# Altium schematic sheet PSU.SchDoc
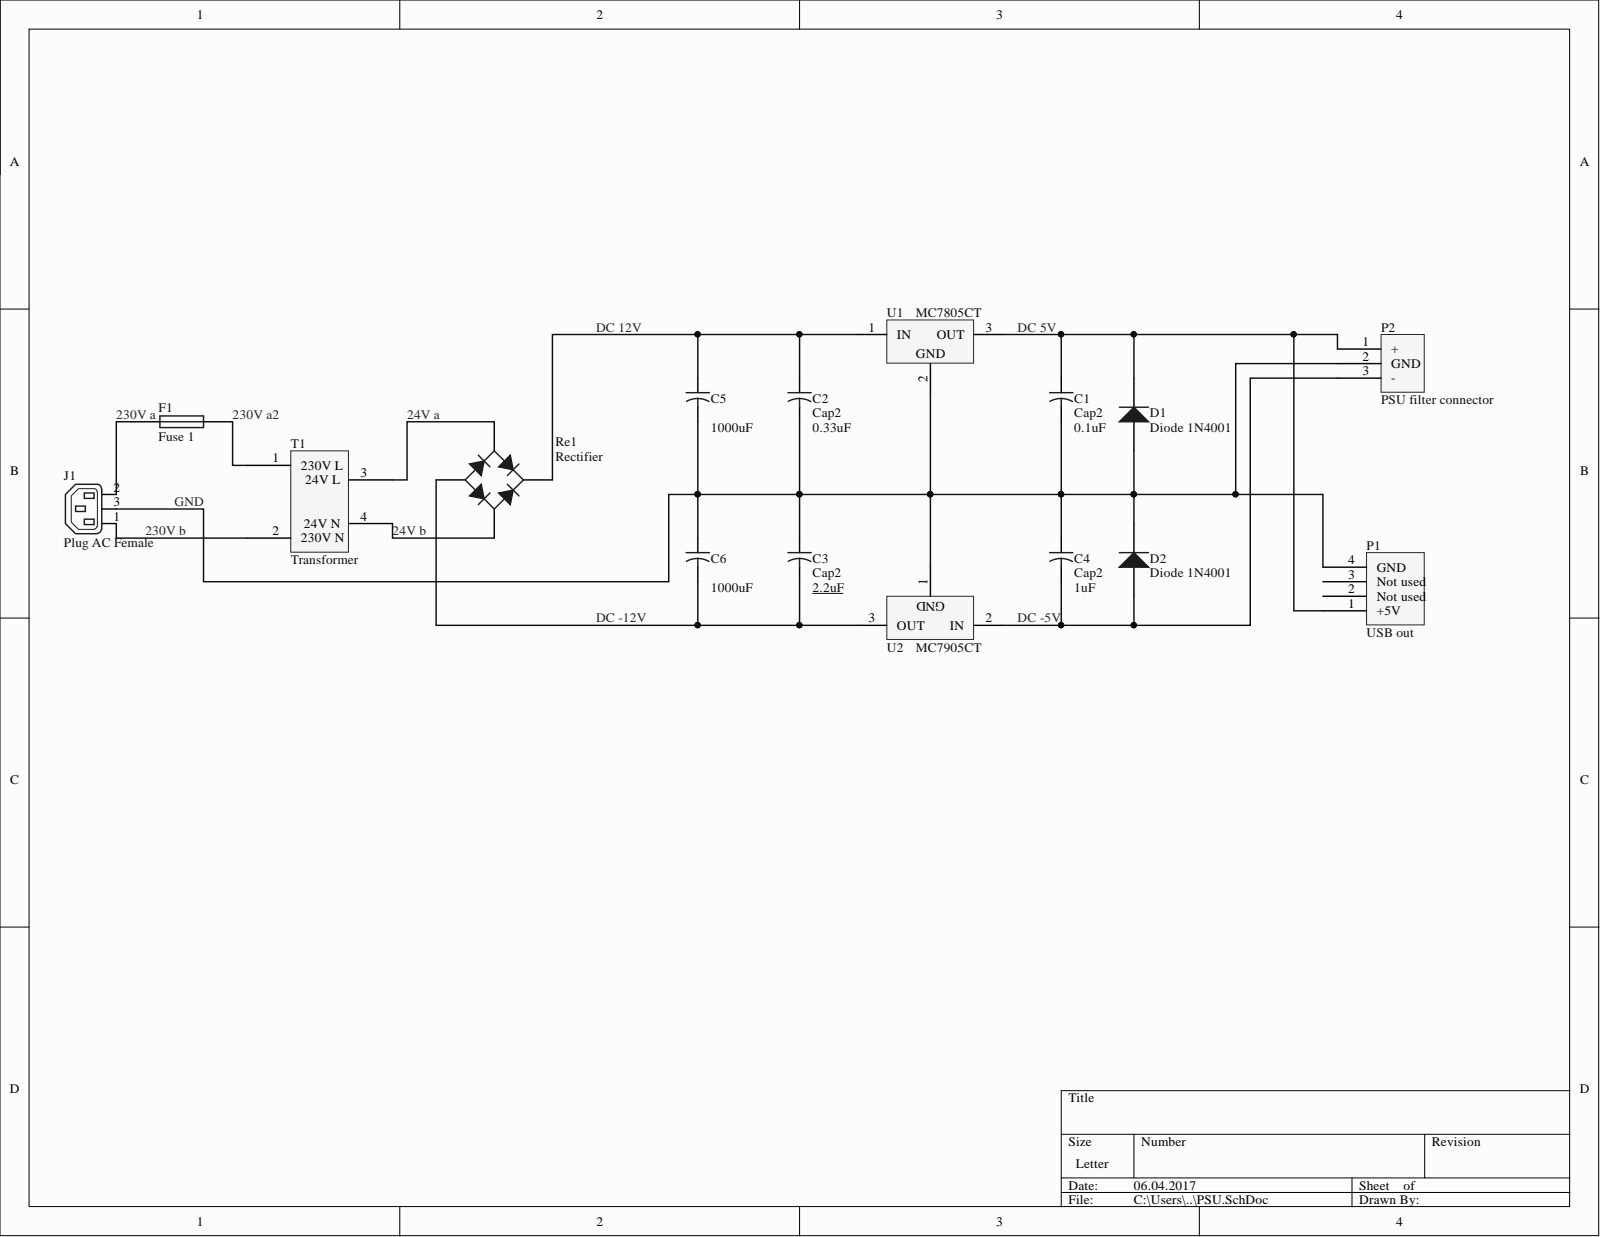
[diagram p0: PSU.SchDoc]

## Parts
C1  Cap2  value 0.1uF  [Cap Jamicon 1uF]
C2  Cap2  value 0.33uF  [Cap Jamicon 330nF]
C3  Cap2  value 2.2uF  [Cap Panasonic 2.2uF]
C4  Cap2  value 1uF  [Cap Jamicon 1uF]
C5  value 1000uF  [Cap 1000uF]
C6  value 1000uF  [Cap 1000uF]
D1  Diode 1N4001  [DO-41]
D2  Diode 1N4001  [DO-41]
F1  Fuse 1  [PIN-W2/E2.8]
J1  Plug AC Female  [Power input PSU]
P1  USB out  [USB out]
P2  PSU filter connector  [PSU filter connector]
Re1  Rectifier  [Rectifier PSU]
T1  Transformer  [Transformator]
U1  MC7805CT  [221A-06]
U2  MC7905CT  [221A-09]

## Nets
24V a: Re1.4 T1.3(24V_L)
24V b: Re1.2 T1.4(24V_N)
230V a: F1.1 J1.2
230V a2: F1.2 T1.1(230V_L)
230V b: J1.1 T1.2(230V_N)
DC 5V: C1.1 D1.2 P1.1(+5V) P2.1 U1.3
DC 12V: C2.1 C5.1 Re1.1 U1.1
DC -5V: C4.2 D2.1 P2.3 U2.2
DC -12V: C3.2 C6.2 Re1.3 U2.3
GND: C1.2 C2.2 C3.1 C4.1 C5.2 C6.1 D1.1 D2.2 J1.3 P1.4(GND) P2.2 U1.2 U2.1
N00011:

Not connected: P1 2(Not_used) 3(Not_used)

## Library data of the parts
C1-C4 = Cap2: Capacitor; Component Kind: Standard; Library Name: Miscellaneous Devices.IntLib; PackageDescription: Capacitor; 2 Leads; PackageReference: CAPR5-4X5; Published: 23-Sep-2002; Signal Integrity: Cap; Simulation: CAP; Publisher: Altium Limited
C5 C6 = Cap2: Cap; Component Kind: Standard; PackageDescription: Capacitor; 2 Leads; PackageReference: CAPR5-4X5; Published: 23-Sep-2002; Signal Integrity: Cap; Simulation: CAP; Library Name: PSU.SCHLIB; Publisher: Altium Limited
D1 D2 = Diode 1N4001: 1 Amp General Purpose Rectifier; Code_JEDEC: DO-204-AL; Component Kind: Standard; ComponentLink1Description: Manufacturer Link; ComponentLink1URL: http://www.fairchildsemi.com/; ComponentLink2Description: Datasheet; ComponentLink2URL: http://www.fairchildsemi.com/ds/1N%2F1N4001.pdf; DatasheetVersion: 1998; LatestRevisionDate: 17-Jul-2002; LatestRevisionNote: Re-released for DXP Platform.; Library Name: Miscellaneous Devices.IntLib; PackageDescription: Diode, Axial; Body 5.2 x 2.7 mm (LxDia. max), Lead Dia. 0.86 mm (max); PackageReference: DO-41; PackageVersion: Aug-1999; Published: 8-Jun-2000; Signal Integrity: 1N4001; Simulation: 1N4001; Publisher: Altium Limited
F1 = Fuse 1: Fuse; Component Kind: Standard; LatestRevisionDate: 17-Jul-2002; LatestRevisionNote: Re-released for DXP Platform.; Library Name: Miscellaneous Devices.IntLib; PackageDescription: Thru-Hole; 2 Leads; PackageReference: PIN-W2/E2.8; Published: 8-Jun-2000; Simulation: FUSE; Publisher: Altium Limited
J1 = Plug AC Female: IEC Mains Power Outlet, EN60 320-2-2 F Class I, PC Flange Rear Mount, Chassis Socket; DrillSize: Corresponds to nominal value.; MaximumRating: 10A, 250V a.c. (UL & CSA 15A, 250V a.c.); MountingFixtures: Not drawn on schematic symbol.; PackageDocument: ver.80, 15-Sep-2003; PCB_Layout: Complies with manufacturer's recommendation.; Component Kind: Standard; ComponentLink1Description: Manufacturer Link; ComponentLink1URL: http://www.bulgin.co.uk/; DatasheetDocument: ver.80, 15-Sep-2003; LatestRevisionDate: 15-Jul-2004; LatestRevisionNote: Component Replaced & renamed from "Phonejack".; Library Name: Miscellaneous Connectors.IntLib; PackageReference: PX0675/PC; Published: 15-Jul-2004; Terminations: P.C. Spills; Publisher: Altium Limited
Re1 = Bridge1 Resonator PSU: Full Wave Diode Bridge; Component Kind: Standard; DatasheetVersion: E2732B; LatestRevisionDate: 29-May-2009; LatestRevisionNote: Sch pins A & K swapped.; Library Name: PSU.SCHLIB; PackageDescription: Bridge Rectifier; 4 Terminals; Body 9.4 x 9.4 mm (typ); Pitch 5.08 mm; PackageReference: D-38; Published: 8-Jun-2000; Simulation: BRIDGE; Publisher: Altium Limited
U1 = MC7805CT: Three-Terminal Positive Voltage Regulator; Note: Heatsink surface is connected to Pin 2; Code_JEDEC: TO-262-AA; Component Kind: Standard; ComponentLink1Description: Manufacturer Link; ComponentLink1URL: http://www.onsemi.com/site/products/taxonomy/0,4438,503,00.html; ComponentLink2Description: Datasheet; ComponentLink2URL: http://www.onsemi.com/pub/Collateral/MC7800-D.PDF; DatasheetVersion: 1997; Library Name: ON Semi Power Mgt Voltage Regulator.IntLib; PackageDescription: TO, Thru-Hole, Vertical, Heatsink Mounted; 3 In-Line Leads; Pitch 2.54 mm; PackageReference: 221A-06; PackageVersion: 1997; Published: 15-Apr-2002; Publisher: Altium Limited
U2 = MC7905CT: Three-Terminal Negative Voltage Regulator; Note: Heatsink surface connected to Pin 2; Code_JEDEC: TO-262-AA; Component Kind: Standard; ComponentLink1Description: Manufacturer Link; ComponentLink1URL: http://www.onsemi.com/site/products/taxonomy/0,4438,503,00.html; ComponentLink2Description: Datasheet; ComponentLink2URL: http://www.onsemi.com/pub/Collateral/MC7900-D.PDF; DatasheetVersion: 1996; Library Name: ON Semi Power Mgt Voltage Regulator.IntLib; PackageDescription: TO, Thru-Hole, Vertical, Heatsink Mounted; 3 In-Line Leads; Pitch 2.54 mm; PackageReference: 221A-09; Published: 15-Apr-2002; Publisher: Altium Limited
P1 = USB out: Component Kind: Standard; Library Name: PSU.SCHLIB
P2 = PSU filter connector: Component Kind: Standard; Library Name: PSU.SCHLIB
T1 = Transformer: Component Kind: Standard; Library Name: PSU.SCHLIB
